# Altium schematic sheet 025_RTL8305&LANPORT.SchDoc(025_RTL8305&LANPORT)
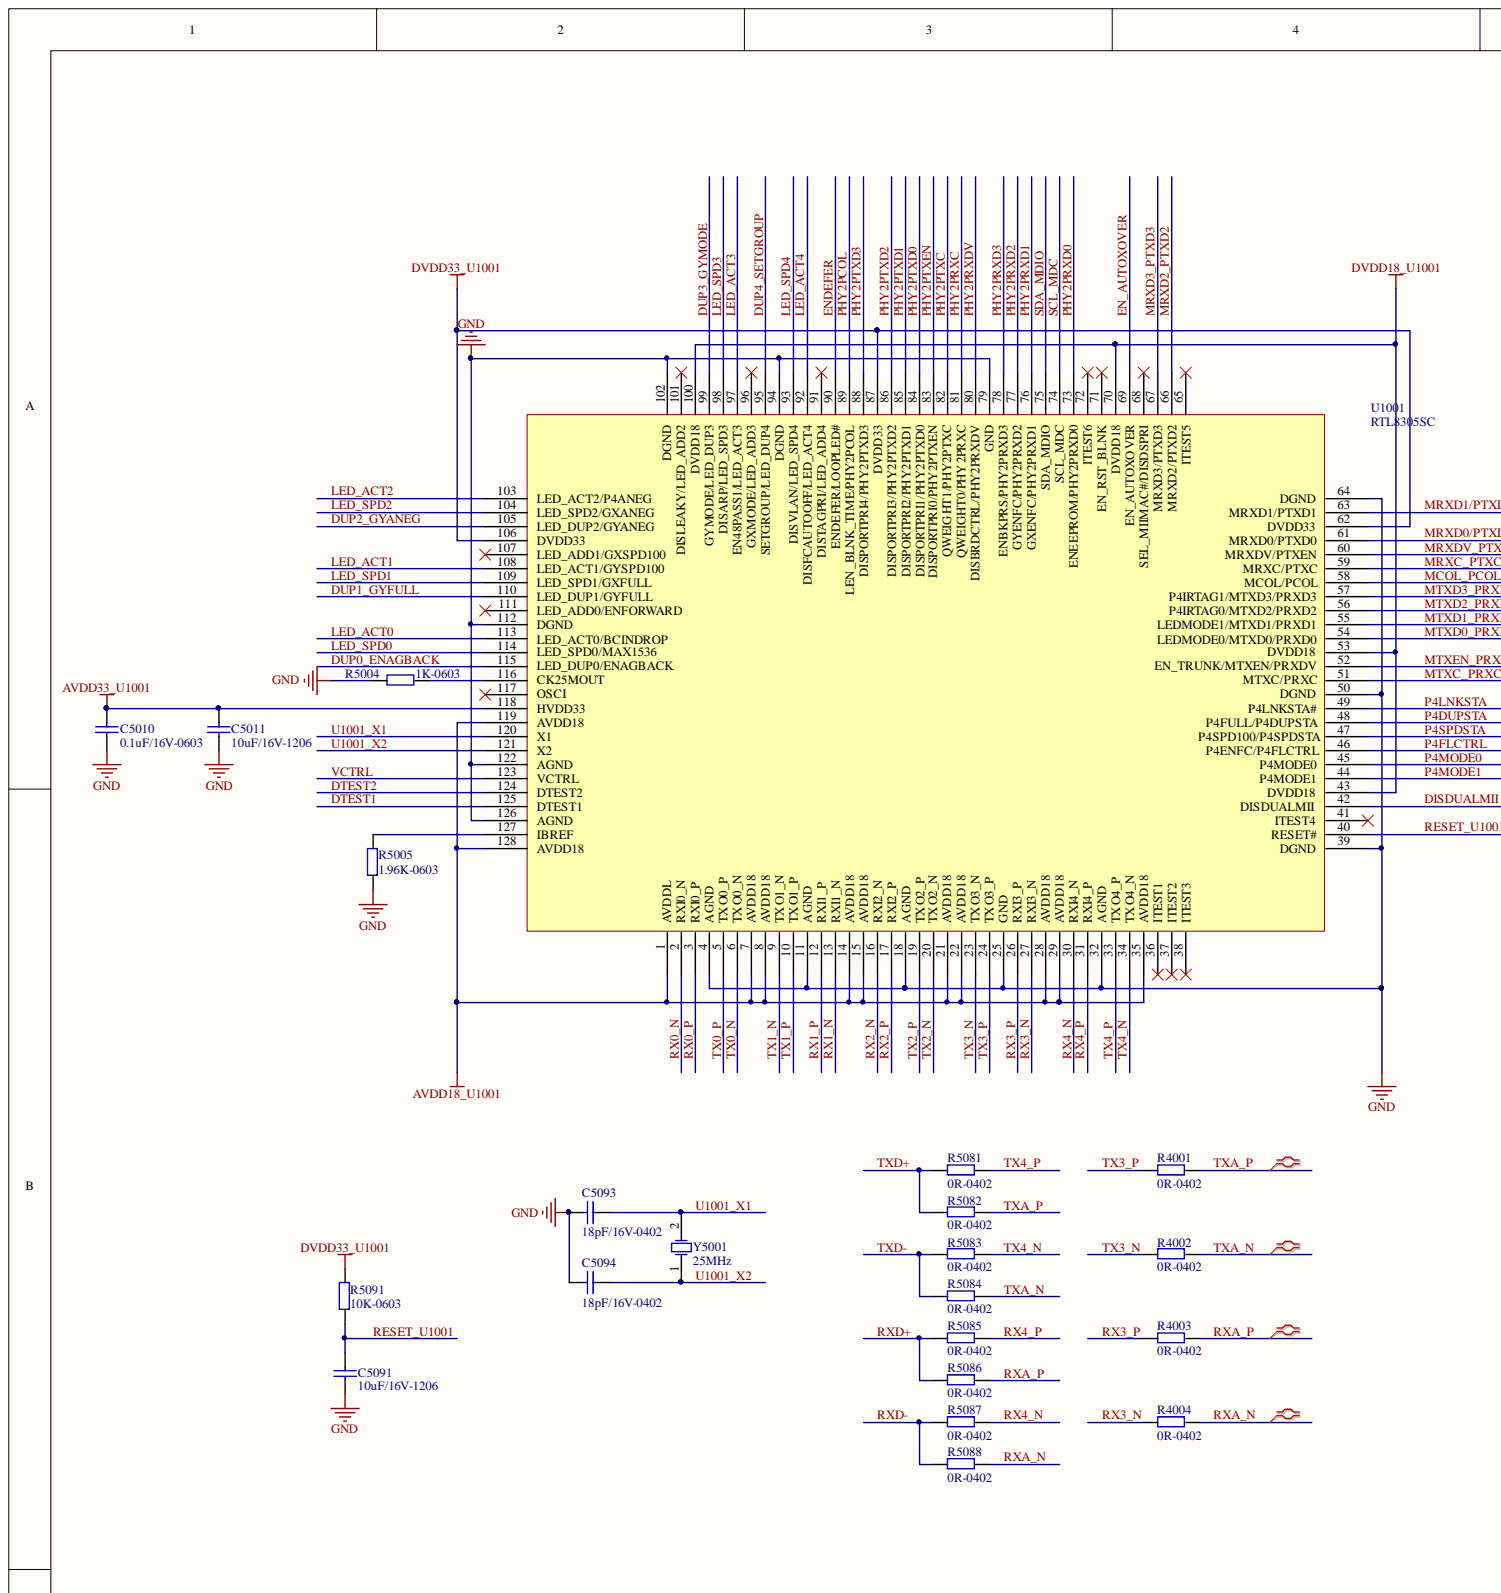
[diagram p0: 025_RTL8305&LANPORT.SchDoc, top left]
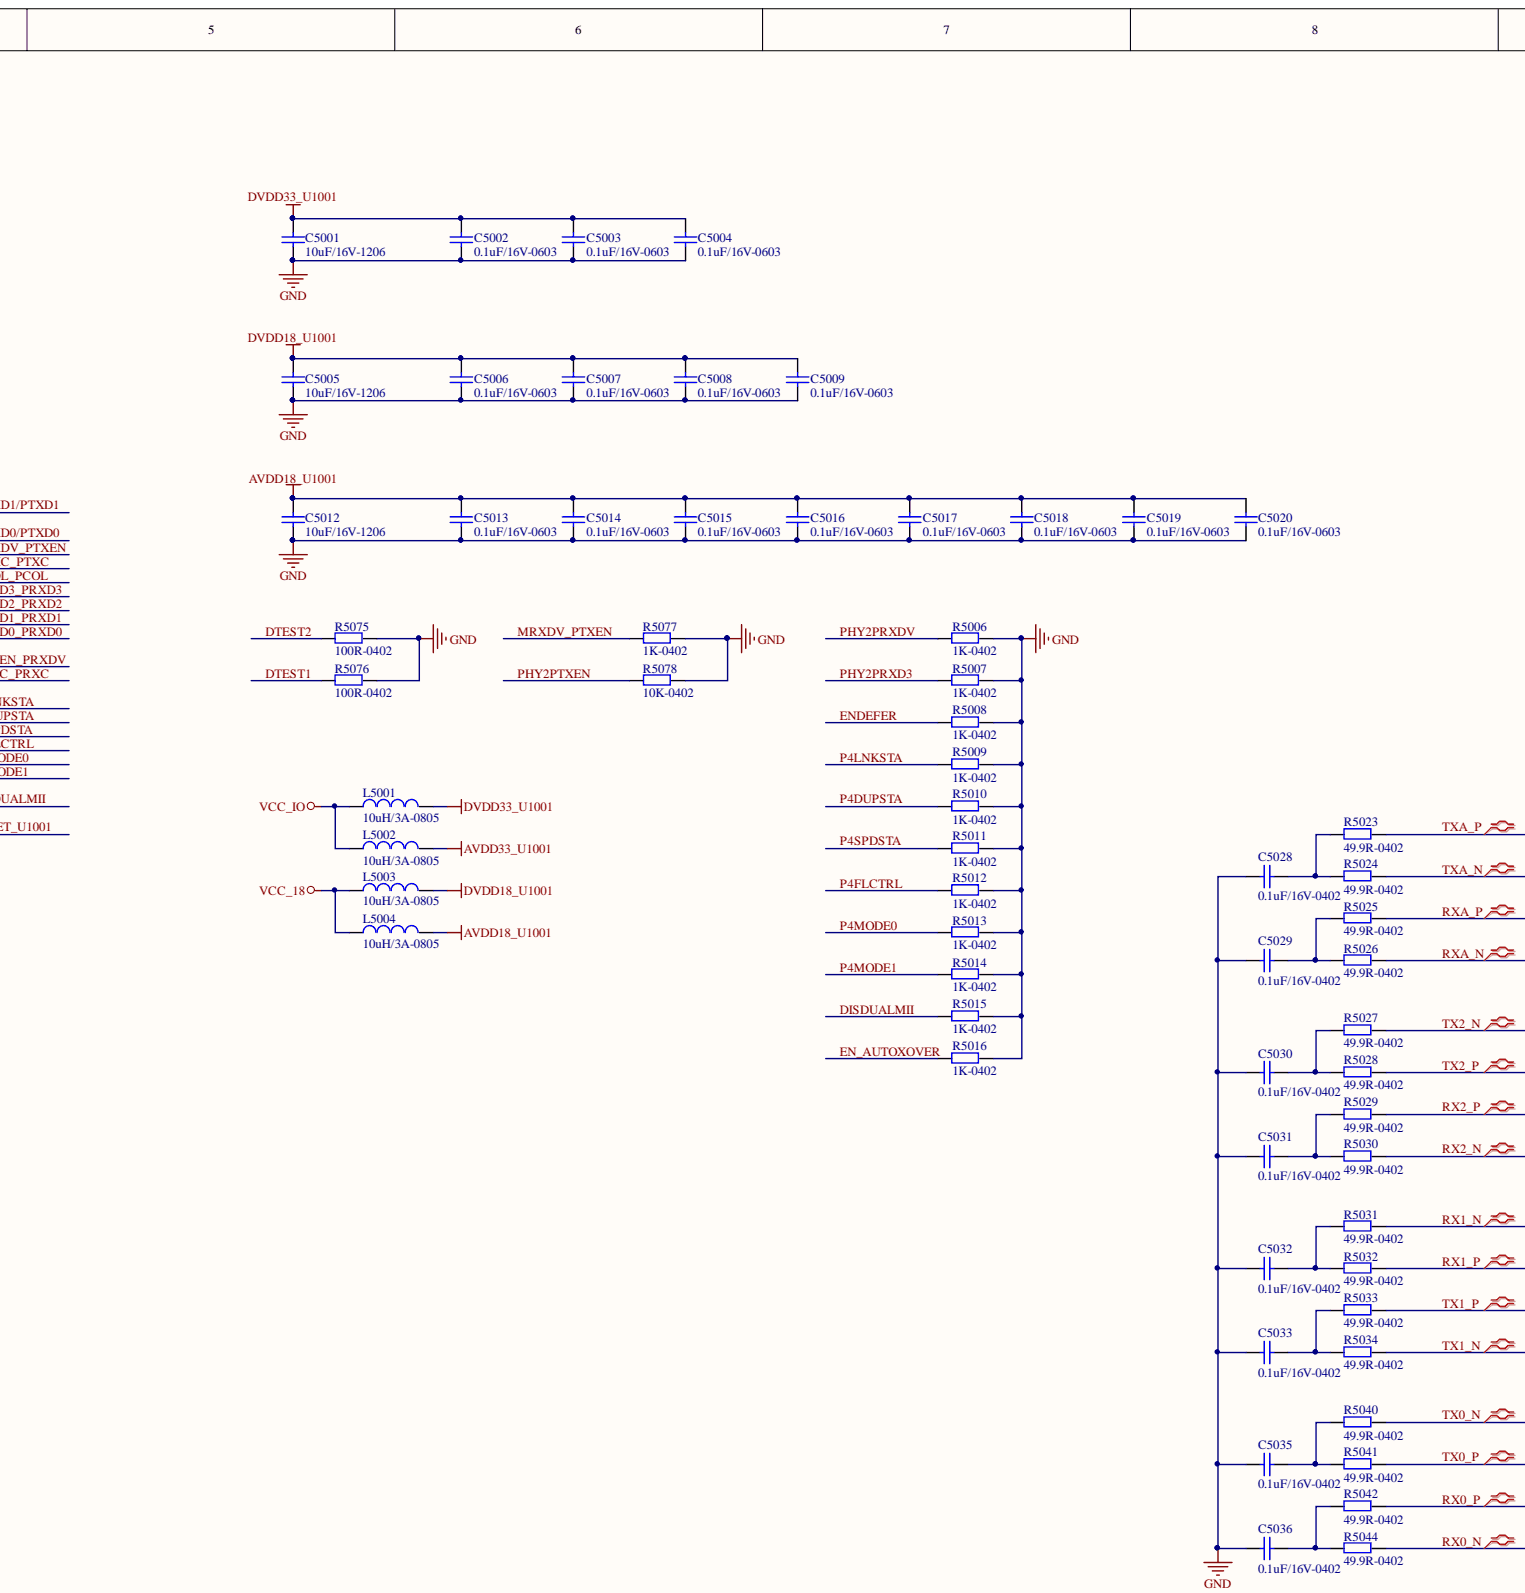
[diagram p1: 025_RTL8305&LANPORT.SchDoc, top centre]
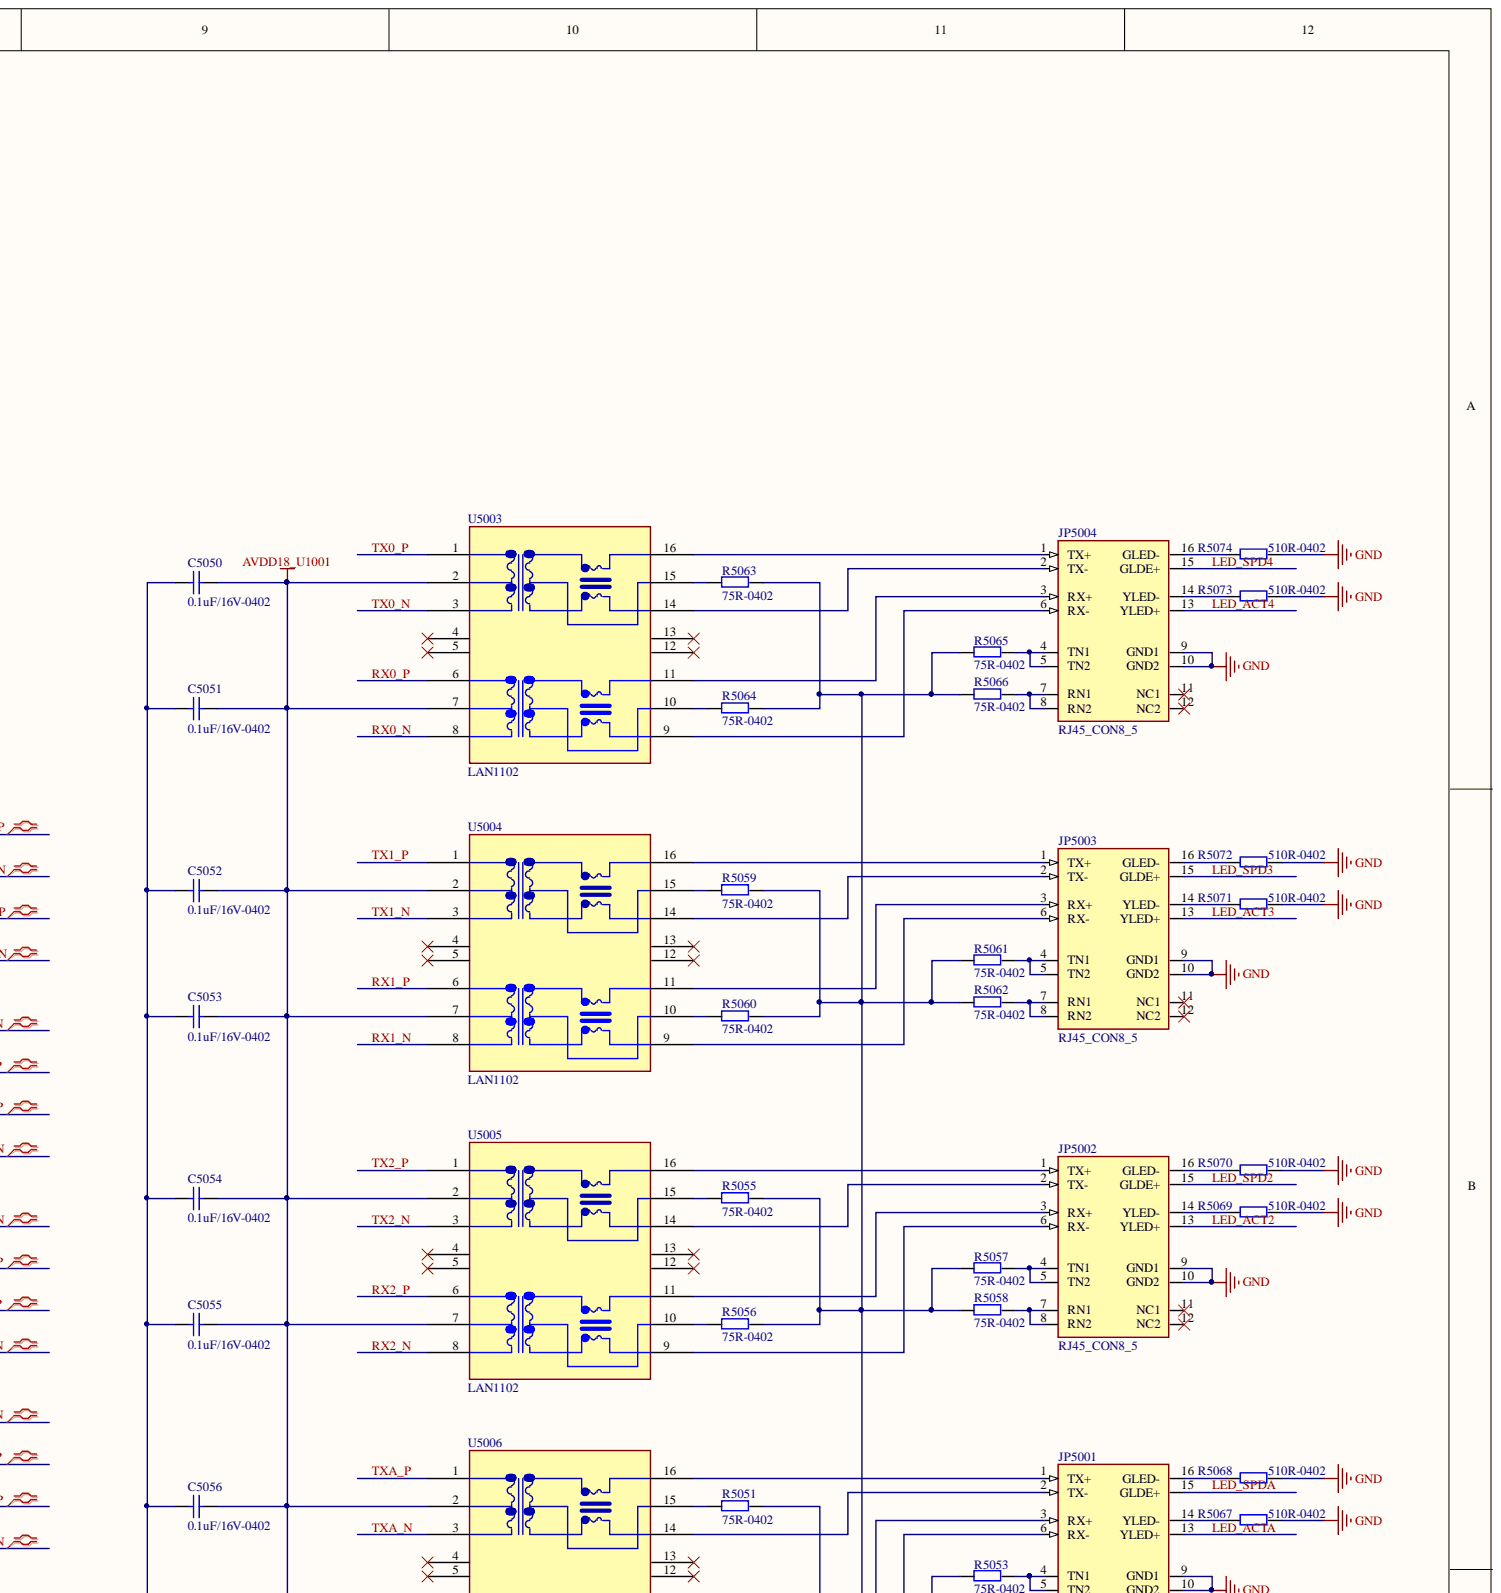
[diagram p2: 025_RTL8305&LANPORT.SchDoc, top right]
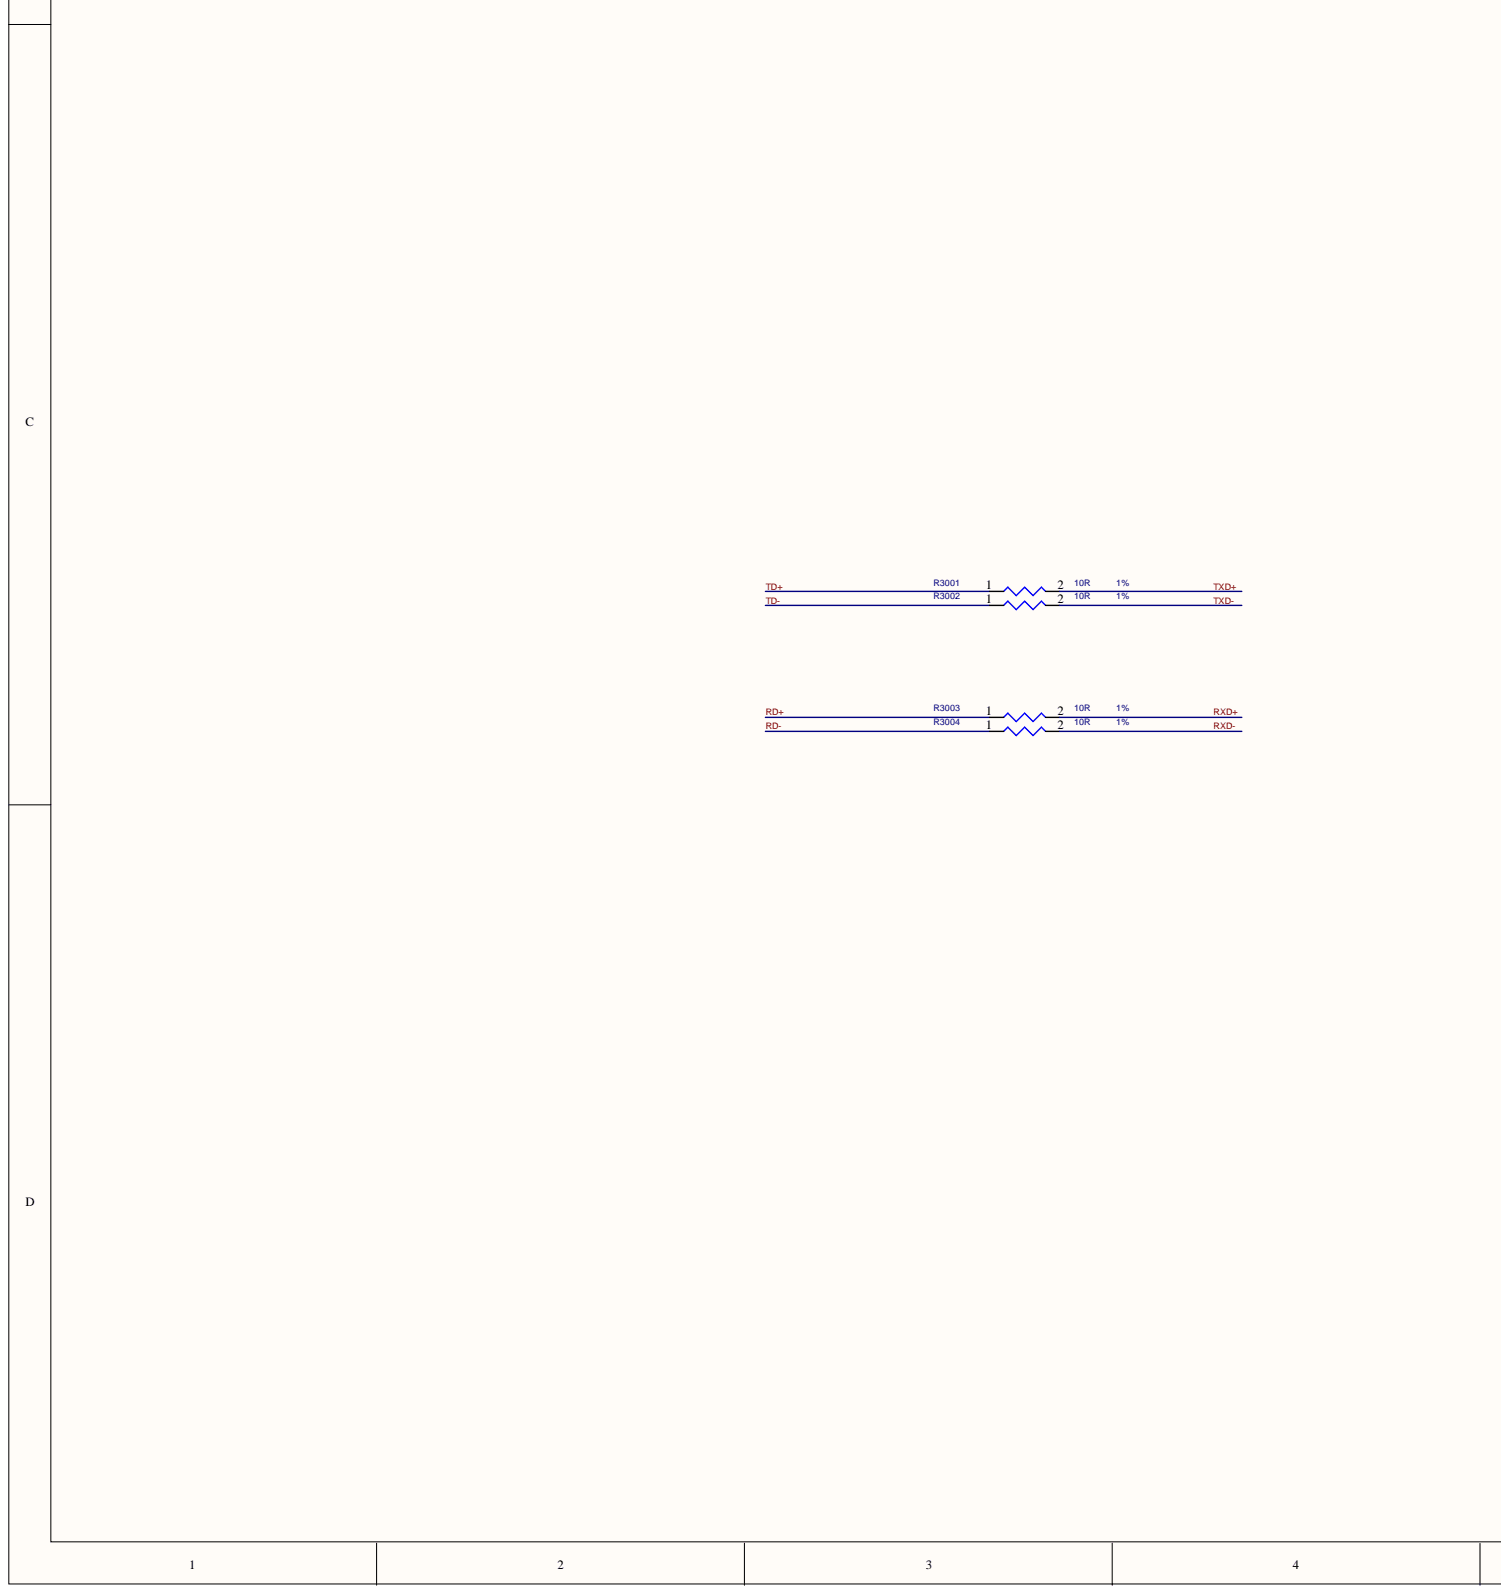
[diagram p3: 025_RTL8305&LANPORT.SchDoc, bottom left]
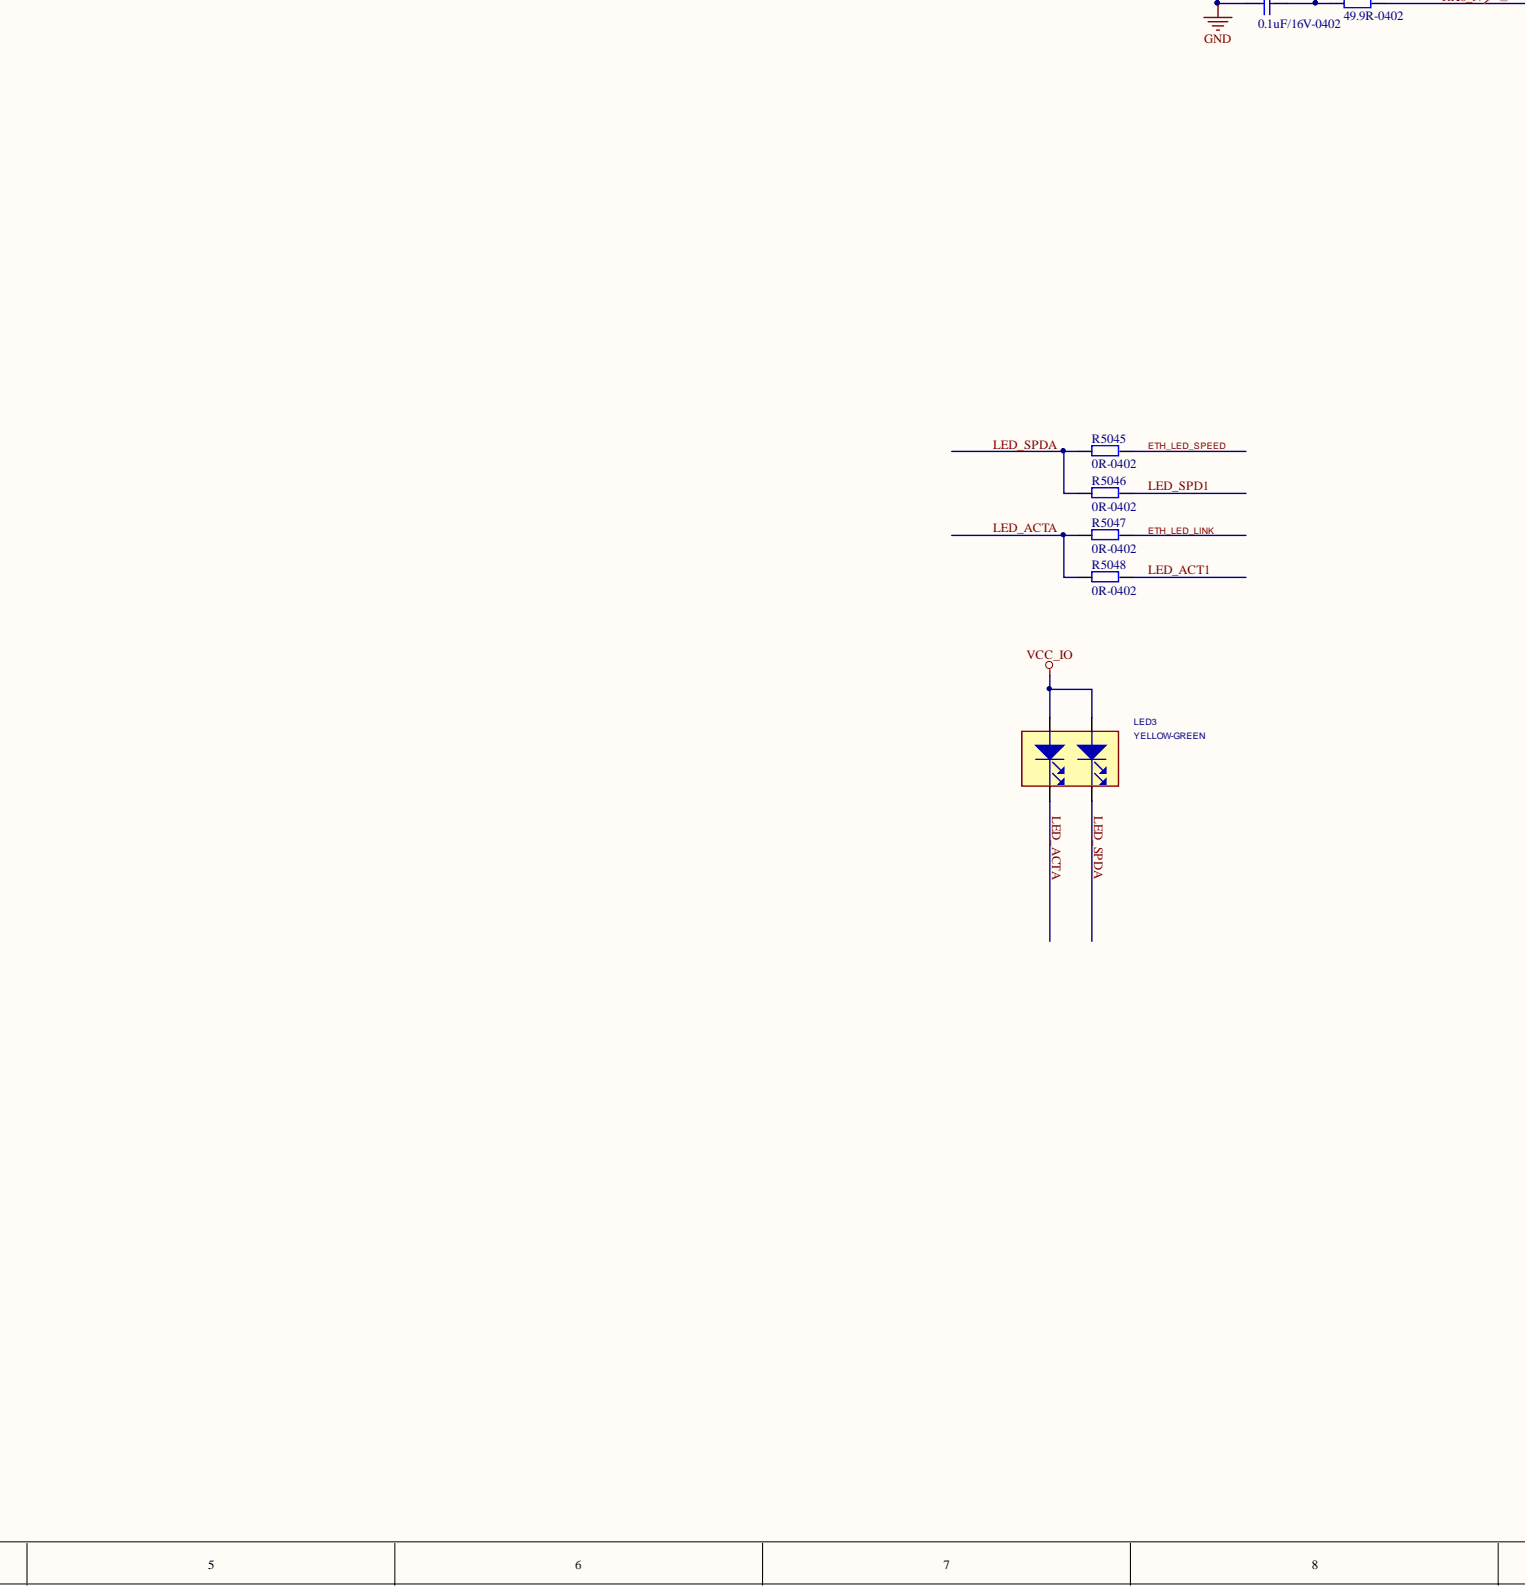
[diagram p4: 025_RTL8305&LANPORT.SchDoc, bottom centre]
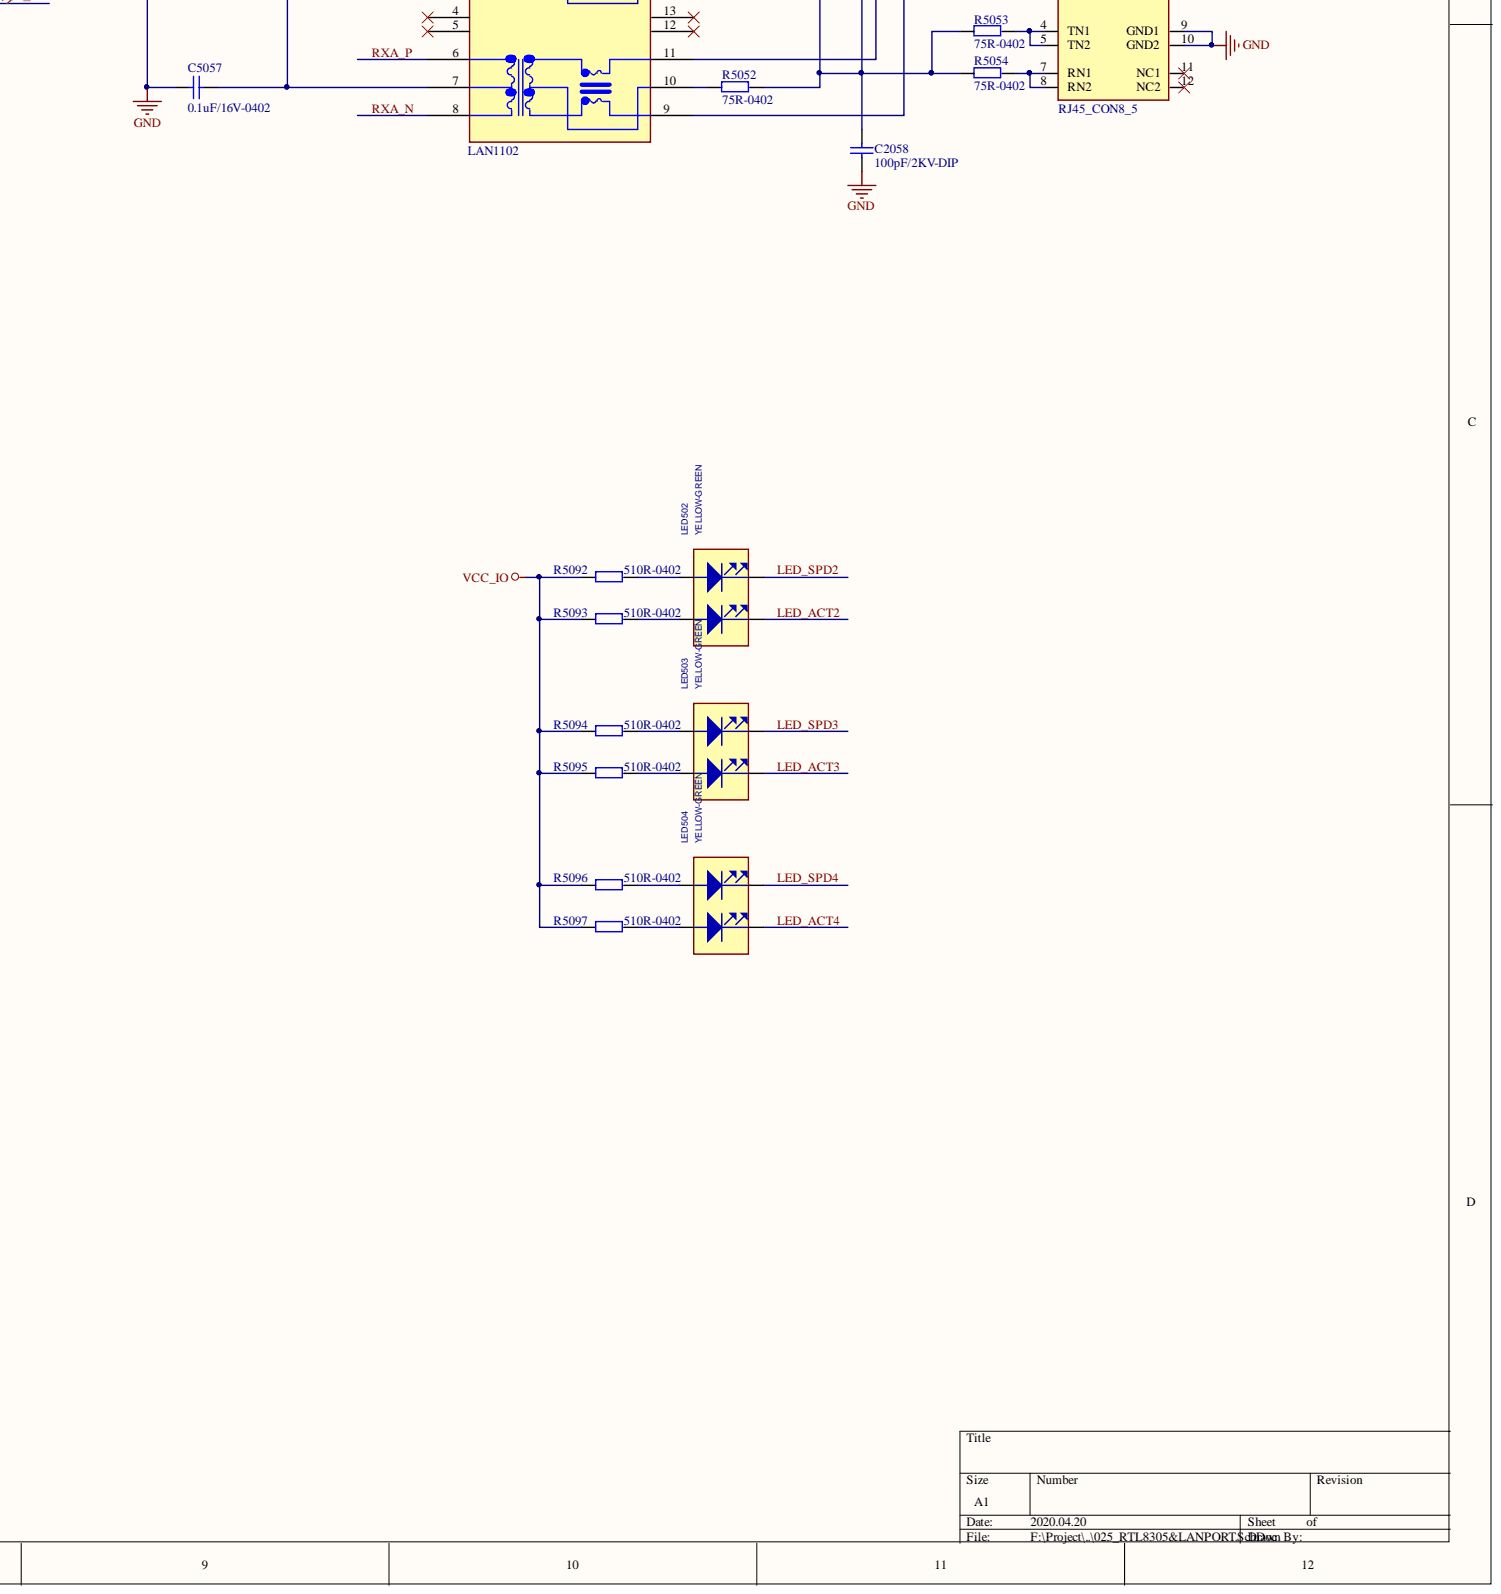
[diagram p5: 025_RTL8305&LANPORT.SchDoc, bottom right]

## Parts
C2058  100pF/2KV-DIP  [RAD-0.1]
C5001  10uF/16V-1206  [C1206]
C5002  0.1uF/16V-0603  [C0603]
C5003  0.1uF/16V-0603  [C0603]
C5004  0.1uF/16V-0603  [C0603]
C5005  10uF/16V-1206  [C1206]
C5006  0.1uF/16V-0603  [C0603]
C5007  0.1uF/16V-0603  [C0603]
C5008  0.1uF/16V-0603  [C0603]
C5009  0.1uF/16V-0603  [C0603]
C5010  0.1uF/16V-0603  [C0603]
C5011  10uF/16V-1206  [C1206]
C5012  10uF/16V-1206  [C1206]
C5013  0.1uF/16V-0603  [C0603]
C5014  0.1uF/16V-0603  [C0603]
C5015  0.1uF/16V-0603  [C0603]
C5016  0.1uF/16V-0603  [C0603]
C5017  0.1uF/16V-0603  [C0603]
C5018  0.1uF/16V-0603  [C0603]
C5019  0.1uF/16V-0603  [C0603]
C5020  0.1uF/16V-0603  [C0603]
C5028  0.1uF/16V-0402  [C0402]
C5029  0.1uF/16V-0402  [C0402]
C5030  0.1uF/16V-0402  [C0402]
C5031  0.1uF/16V-0402  [C0402]
C5032  0.1uF/16V-0402  [C0402]
C5033  0.1uF/16V-0402  [C0402]
C5035  0.1uF/16V-0402  [C0402]
C5036  0.1uF/16V-0402  [C0402]
C5050  0.1uF/16V-0402  [C0402]
C5051  0.1uF/16V-0402  [C0402]
C5052  0.1uF/16V-0402  [C0402]
C5053  0.1uF/16V-0402  [C0402]
C5054  0.1uF/16V-0402  [C0402]
C5055  0.1uF/16V-0402  [C0402]
C5056  0.1uF/16V-0402  [C0402]
C5057  0.1uF/16V-0402  [C0402]
C5091  10uF/16V-1206  [C1206]
C5093  18pF/16V-0402  [C0402]
C5094  18pF/16V-0402  [C0402]
JP5001  RJ45_CON8_5  [RJ-45B]
JP5002  RJ45_CON8_5  [RJ-45B]
JP5003  RJ45_CON8_5  [RJ-45B]
JP5004  RJ45_CON8_5  [RJ-45B]
L5001  10uH/3A-0805  [I0805]
L5002  10uH/3A-0805  [I0805]
L5003  10uH/3A-0805  [I0805]
L5004  10uH/3A-0805  [I0805]
LED3  2ND: YELLOW-GREEN  [LED254_2P]  (hidden comment: LED_R)
LED502  2ND: YELLOW-GREEN  [LED254_2P]  (hidden comment: LED_R)
LED503  2ND: YELLOW-GREEN  [LED254_2P]  (hidden comment: LED_R)
LED504  2ND: YELLOW-GREEN  [LED254_2P]  (hidden comment: LED_R)
R3001  10R  Tolerance: 1%  [R0402]
R3002  10R  Tolerance: 1%  [R0402]
R3003  10R  Tolerance: 1%  [R0402]
R3004  10R  Tolerance: 1%  [R0402]
R4001  0R-0402  [R0402]
R4002  0R-0402  [R0402]
R4003  0R-0402  [R0402]
R4004  0R-0402  [R0402]
R5004  1K-0603  [R0603]
R5005  1.96K-0603  [R0603]
R5006  1K-0402  [R0402]
R5007  1K-0402  [R0402]
R5008  1K-0402  [R0402]
R5009  1K-0402  [R0402]
R5010  1K-0402  [R0402]
R5011  1K-0402  [R0402]
R5012  1K-0402  [R0402]
R5013  1K-0402  [R0402]
R5014  1K-0402  [R0402]
R5015  1K-0402  [R0402]
R5016  1K-0402  [R0402]
R5023  49.9R-0402  [R0402]
R5024  49.9R-0402  [R0402]
R5025  49.9R-0402  [R0402]
R5026  49.9R-0402  [R0402]
R5027  49.9R-0402  [R0402]
R5028  49.9R-0402  [R0402]
R5029  49.9R-0402  [R0402]
R5030  49.9R-0402  [R0402]
R5031  49.9R-0402  [R0402]
R5032  49.9R-0402  [R0402]
R5033  49.9R-0402  [R0402]
R5034  49.9R-0402  [R0402]
R5040  49.9R-0402  [R0402]
R5041  49.9R-0402  [R0402]
R5042  49.9R-0402  [R0402]
R5044  49.9R-0402  [R0402]
R5045  0R-0402  [R0402]
R5046  0R-0402  [R0402]
R5047  0R-0402  [R0402]
R5048  0R-0402  [R0402]
R5051  75R-0402  [R0402]
R5052  75R-0402  [R0402]
R5053  75R-0402  [R0402]
R5054  75R-0402  [R0402]
R5055  75R-0402  [R0402]
R5056  75R-0402  [R0402]
R5057  75R-0402  [R0402]
R5058  75R-0402  [R0402]
R5059  75R-0402  [R0402]
R5060  75R-0402  [R0402]
R5061  75R-0402  [R0402]
R5062  75R-0402  [R0402]
R5063  75R-0402  [R0402]
R5064  75R-0402  [R0402]
R5065  75R-0402  [R0402]
R5066  75R-0402  [R0402]
R5067  510R-0402  [R0402]
R5068  510R-0402  [R0402]
R5069  510R-0402  [R0402]
R5070  510R-0402  [R0402]
R5071  510R-0402  [R0402]
R5072  510R-0402  [R0402]
R5073  510R-0402  [R0402]
R5074  510R-0402  [R0402]
R5075  100R-0402  [R0402]
R5076  100R-0402  [R0402]
R5077  1K-0402  [R0402]
R5078  10K-0402  [R0402]
R5081  0R-0402  [R0402]
R5082  0R-0402  [R0402]
R5083  0R-0402  [R0402]
R5084  0R-0402  [R0402]
R5085  0R-0402  [R0402]
R5086  0R-0402  [R0402]
R5087  0R-0402  [R0402]
R5088  0R-0402  [R0402]
R5091  10K-0603  [R0603]
R5092  510R-0402  [R0402]
R5093  510R-0402  [R0402]
R5094  510R-0402  [R0402]
R5095  510R-0402  [R0402]
R5096  510R-0402  [R0402]
R5097  510R-0402  [R0402]
U1001  RTL8305SC  [PQFP-128N]
U5003  LAN1102  [SOIC16]
U5004  LAN1102  [SOIC16]
U5005  LAN1102  [SOIC16]
U5006  LAN1102  [SOIC16]
Y5001  25MHz  [XTAL-RU49/4]

## Nets
AVDD18_U1001: C5012.2 C5013.2 C5014.2 C5015.2 C5016.2 C5017.2 C5018.2 C5019.2 C5020.2 C5050.2 C5051.2 C5052.2 C5053.2 C5054.2 C5055.2 C5056.2 C5057.2 L5004.2 U1001.1(AVDDL) U1001.7(AVDD18) U1001.8(AVDD18) U1001.14(AVDD18) U1001.15(AVDD18) U1001.21(AVDD18) U1001.22(AVDD18) U1001.28(AVDD18) U1001.29 U1001.35(AVDD18) U1001.119(AVDD18) U1001.128(AVDD18) U5003.2 U5003.7 U5004.2 U5004.7 U5005.2 U5005.7 U5006.2 U5006.7
AVDD33_U1001: C5010.2 C5011.2 L5002.2 U1001.118(HVDD33)
DVDD18_U1001: C5005.2 C5006.2 C5007.2 C5008.2 C5009.2 L5003.2 U1001.43(DVDD18) U1001.53(DVDD18) U1001.70 U1001.100(DVDD18)
DVDD33_U1001: C5001.2 C5002.2 C5003.2 C5004.2 L5001.2 R5091.2 U1001.62(DVDD33) U1001.87(DVDD33) U1001.106(DVDD33)
GND: C2058.1 C5001.1 C5002.1 C5003.1 C5004.1 C5005.1 C5006.1 C5007.1 C5008.1 C5009.1 C5010.1 C5011.1 C5012.1 C5013.1 C5014.1 C5015.1 C5016.1 C5017.1 C5018.1 C5019.1 C5020.1 C5028.1 C5029.1 C5030.1 C5031.1 C5032.1 C5033.1 C5035.1 C5036.1 C5050.1 C5051.1 C5052.1 C5053.1 C5054.1 C5055.1 C5056.1 C5057.1 C5091.1 C5093.2 C5094.2 JP5001.9(GND1) JP5001.10(GND2) JP5002.9(GND1) JP5002.10(GND2) JP5003.9(GND1) JP5003.10(GND2) JP5004.9(GND1) JP5004.10(GND2) R5004.1 R5005.1 R5006.2 R5007.2 R5008.2 R5009.2 R5010.2 R5011.2 R5012.2 R5013.2 R5014.2 R5015.2 R5016.2 R5067.2 R5068.2 R5069.2 R5070.2 R5071.2 R5072.2 R5073.2 R5074.2 R5075.1 R5076.1 R5077.1 R5078.1 U1001.4(AGND) U1001.11(AGND) U1001.18 U1001.25(GND) U1001.32(AGND) U1001.39(DGND) U1001.50(DGND) U1001.64(DGND) U1001.79(GND) U1001.94 U1001.102(DGND) U1001.112(DGND) U1001.122(AGND) U1001.126(AGND)
VCC_18: L5003.1 L5004.1
VCC_IO: L5001.1 L5002.1 LED3.1 LED3.3 R5092.1 R5093.1 R5094.1 R5095.1 R5096.1 R5097.1
DISDUALMII: R5015.1 U1001.42(DISDUALMII)
DTEST1: R5076.2 U1001.125(DTEST1)
DTEST2: R5075.2 U1001.124(DTEST2)
DUP0_ENAGBACK: U1001.115(LED_DUP0/ENAGBACK)
DUP1_GYFULL: U1001.110(LED_DUP1/GYFULL)
DUP2_GYANEG: U1001.105(LED_DUP2/GYANEG)
DUP3_GYMODE: U1001.99(GYMODE/LED_DUP3)
DUP4_SETGROUP: U1001.95(SETGROUP/LED_DUP4)
EN_AUTOXOVER: R5016.1 U1001.69(EN_AUTOXOVER)
ENDEFER: R5008.1 U1001.90
ETH_LED_LINK: R5047.2
ETH_LED_SPEED: R5045.2
LED_ACT0: U1001.113(LED_ACT0/BCINDROP)
LED_ACT1: R5048.2 U1001.108(LED_ACT1/GYSPD100)
LED_ACT2: JP5002.13(YLED+) LED502.2 U1001.103(LED_ACT2/P4ANEG)
LED_ACT3: JP5003.13(YLED+) LED503.2 U1001.97(EN48PASS1/LED_ACT3)
LED_ACT4: JP5004.13(YLED+) LED504.2 U1001.92(DISFCAUTOOFF/LED_ACT4)
LED_ACTA: JP5001.13(YLED+) LED3.2 R5047.1 R5048.1
LED_SPD0: U1001.114(LED_SPD0/MAX1536)
LED_SPD1: R5046.2 U1001.109(LED_SPD1/GXFULL)
LED_SPD2: JP5002.15(GLDE+) LED502.4 U1001.104(LED_SPD2/GXANEG)
LED_SPD3: JP5003.15(GLDE+) LED503.4 U1001.98(DISARP/LED_SPD3)
LED_SPD4: JP5004.15(GLDE+) LED504.4 U1001.93(DISVLAN/LED_SPD4)
LED_SPDA: JP5001.15(GLDE+) LED3.4 R5045.1 R5046.1
MCOL_PCOL: U1001.58(MCOL/PCOL)
MRXC_PTXC: U1001.59(MRXC/PTXC)
MRXD0/PTXD0: U1001.61(MRXD0/PTXD0)
MRXD1/PTXD1: U1001.63(MRXD1/PTXD1)
MRXD2_PTXD2: U1001.66(MRXD2/PTXD2)
MRXD3_PTXD3: U1001.67
MRXDV_PTXEN: R5077.2 U1001.60(MRXDV/PTXEN)
MTXC_PRXC: U1001.51(MTXC/PRXC)
MTXD0_PRXD0: U1001.54(LEDMODE0/MTXD0/PRXD0)
MTXD1_PRXD1: U1001.55(LEDMODE1/MTXD1/PRXD1)
MTXD2_PRXD2: U1001.56(P4IRTAG0/MTXD2/PRXD2)
MTXD3_PRXD3: U1001.57(P4IRTAG1/MTXD3/PRXD3)
MTXEN_PRXDV: U1001.52(EN_TRUNK/MTXEN/PRXDV)
P4DUPSTA: R5010.1 U1001.48(P4FULL/P4DUPSTA)
P4FLCTRL: R5012.1 U1001.46(P4ENFC/P4FLCTRL)
P4LNKSTA: R5009.1 U1001.49(P4LNKSTA#)
P4MODE0: R5013.1 U1001.45(P4MODE0)
P4MODE1: R5014.1 U1001.44(P4MODE1)
P4SPDSTA: R5011.1 U1001.47(P4SPD100/P4SPDSTA)
PHY2PCOL: U1001.89(LEN_BLNK_TIME/PHY2PCOL)
PHY2PRXC: U1001.81(QWEIGHT0/PHY2PRXC)
PHY2PRXD0: U1001.73(ENEEPROM/PHY2PRXD0)
PHY2PRXD1: U1001.76(GXENFC/PHY2PRXD1)
PHY2PRXD2: U1001.77(GYENFC/PHY2PRXD2)
PHY2PRXD3: R5007.1 U1001.78(ENBKPRS/PHY2PRXD3)
PHY2PRXDV: R5006.1 U1001.80(DISBRDCTRL/PHY2PRXDV)
PHY2PTXC: U1001.82(QWEIGHT1/PHY2PTXC)
PHY2PTXD0: U1001.84(DISPORTPRI1/PHY2PTXD0)
PHY2PTXD1: U1001.85
PHY2PTXD2: U1001.86
PHY2PTXD3: U1001.88(DISPORTPRI4/PHY2PTXD3)
PHY2PTXEN: R5078.2 U1001.83(DISPORTPRI0/PHY2PTXEN)
RD+: R3003.1
RD-: R3004.1
RESET_U1001: C5091.2 R5091.1 U1001.40(RESET#)
RX0_N: R5044.2 U1001.2 U5003.8
RX0_P: R5042.2 U1001.3(RXI0_P) U5003.6
RX1_N: R5031.2 U1001.13 U5004.8
RX1_P: R5032.2 U1001.12(RXI1_P) U5004.6
RX2_N: R5030.2 U1001.16(RXI2_N) U5005.8
RX2_P: R5029.2 U1001.17 U5005.6
RX3_N: R4004.1 U1001.27(RXI3_N)
RX3_P: R4003.1 U1001.26(RXI3_P)
RX4_N: R5087.2 U1001.30(RXI4_N)
RX4_P: R5085.2 U1001.31(RXI4_P)
RXA_N: R4004.2 R5026.2 R5088.2 U5006.8
RXA_P: R4003.2 R5025.2 R5086.2 U5006.6
RXD+: R3003.2 R5085.1 R5086.1
RXD-: R3004.2 R5087.1 R5088.1
SCL_MDC: U1001.74
SDA_MDIO: U1001.75(SDA_MDIO)
TD+: R3001.1
TD-: R3002.1
TX0_N: R5040.2 U1001.6(TXO0_N) U5003.3
TX0_P: R5041.2 U1001.5(TXO0_P) U5003.1
TX1_N: R5034.2 U1001.9 U5004.3
TX1_P: R5033.2 U1001.10(TXO1_P) U5004.1
TX2_N: R5027.2 U1001.20(TXO2_N) U5005.3
TX2_P: R5028.2 U1001.19(TXO2_P) U5005.1
TX3_N: R4002.1 U1001.23(TXO3_N)
TX3_P: R4001.1 U1001.24(TXO3_P)
TX4_N: R5083.2 U1001.34(TXO4_N)
TX4_P: R5081.2 U1001.33
TXA_N: R4002.2 R5024.2 R5084.2 U5006.3
TXA_P: R4001.2 R5023.2 R5082.2 U5006.1
TXD+: R3001.2 R5081.1 R5082.1
TXD-: R3002.2 R5083.1 R5084.1
U1001_X1: C5093.1 U1001.120(X1) Y5001.2
U1001_X2: C5094.1 U1001.121(X2) Y5001.1
VCTRL: U1001.123(VCTRL)
NetC2058_2: C2058.2 R5051.1 R5052.1 R5053.2 R5054.2 R5055.1 R5056.1 R5057.2 R5058.2 R5059.1 R5060.1 R5061.2 R5062.2 R5063.1 R5064.1 R5065.2 R5066.2
NetC5028_2: C5028.2 R5023.1 R5024.1
NetC5029_2: C5029.2 R5025.1 R5026.1
NetC5030_2: C5030.2 R5027.1 R5028.1
NetC5031_2: C5031.2 R5029.1 R5030.1
NetC5032_2: C5032.2 R5031.1 R5032.1
NetC5033_2: C5033.2 R5033.1 R5034.1
NetC5035_2: C5035.2 R5040.1 R5041.1
NetC5036_2: C5036.2 R5042.1 R5044.1
NetJP5001_1: JP5001.1(TX+) U5006.16
NetJP5001_2: JP5001.2(TX-) U5006.14
NetJP5001_3: JP5001.3(RX+) U5006.11
NetJP5001_4: JP5001.4(TN1) JP5001.5(TN2) R5053.1
NetJP5001_6: JP5001.6(RX-) U5006.9
NetJP5001_7: JP5001.7(RN1) JP5001.8(RN2) R5054.1
NetJP5001_14: JP5001.14(YLED-) R5067.1
NetJP5001_16: JP5001.16(GLED-) R5068.1
NetJP5002_1: JP5002.1(TX+) U5005.16
NetJP5002_2: JP5002.2(TX-) U5005.14
NetJP5002_3: JP5002.3(RX+) U5005.11
NetJP5002_4: JP5002.4(TN1) JP5002.5(TN2) R5057.1
NetJP5002_6: JP5002.6(RX-) U5005.9
NetJP5002_7: JP5002.7(RN1) JP5002.8(RN2) R5058.1
NetJP5002_14: JP5002.14(YLED-) R5069.1
NetJP5002_16: JP5002.16(GLED-) R5070.1
NetJP5003_1: JP5003.1(TX+) U5004.16
NetJP5003_2: JP5003.2(TX-) U5004.14
NetJP5003_3: JP5003.3(RX+) U5004.11
NetJP5003_4: JP5003.4(TN1) JP5003.5(TN2) R5061.1
NetJP5003_6: JP5003.6(RX-) U5004.9
NetJP5003_7: JP5003.7(RN1) JP5003.8(RN2) R5062.1
NetJP5003_14: JP5003.14(YLED-) R5071.1
NetJP5003_16: JP5003.16(GLED-) R5072.1
NetJP5004_1: JP5004.1(TX+) U5003.16
NetJP5004_2: JP5004.2(TX-) U5003.14
NetJP5004_3: JP5004.3(RX+) U5003.11
NetJP5004_4: JP5004.4(TN1) JP5004.5(TN2) R5065.1
NetJP5004_6: JP5004.6(RX-) U5003.9
NetJP5004_7: JP5004.7(RN1) JP5004.8(RN2) R5066.1
NetJP5004_14: JP5004.14(YLED-) R5073.1
NetJP5004_16: JP5004.16(GLED-) R5074.1
NetLED502_1: LED502.1 R5093.2
NetLED502_3: LED502.3 R5092.2
NetLED503_1: LED503.1 R5095.2
NetLED503_3: LED503.3 R5094.2
NetLED504_1: LED504.1 R5097.2
NetLED504_3: LED504.3 R5096.2
NetR5004_2: R5004.2 U1001.116(CK25MOUT)
NetR5005_2: R5005.2 U1001.127(IBREF)
NetR5051_2: R5051.2 U5006.15
NetR5052_2: R5052.2 U5006.10
NetR5055_2: R5055.2 U5005.15
NetR5056_2: R5056.2 U5005.10
NetR5059_2: R5059.2 U5004.15
NetR5060_2: R5060.2 U5004.10
NetR5063_2: R5063.2 U5003.15
NetR5064_2: R5064.2 U5003.10

Not connected: JP5001 11(NC1) 12(NC2); JP5002 11(NC1) 12(NC2); JP5003 11(NC1) 12(NC2); JP5004 11(NC1) 12(NC2); U1001 36 37(ITEST2) 38(ITEST3) 41(ITEST4) 65(ITEST5) 68(SEL_MIIMAC#/DISDSPRI) 71(EN_RST_BLNK) 72(ITEST6) 91(DISTAGPRI/LED_ADD4) 96(GXMODE/LED_ADD3) 101 107(LED_ADD1/GXSPD100) 111(LED_ADD0/ENFORWARD) 117(OSCI); U5003 4 5 12 13; U5004 4 5 12 13; U5005 4 5 12 13; U5006 4 5 12 13

## Library data of the parts
C2058 C5001-C5020 C5028-C5033 C5035 C5036 C5050-C5057 C5091 C5093 C5094 = Cap: Capacitor; Simulation: CAP; Signal Integrity: Cap; Published: 8-Jun-2000; PackageReference: RAD-0.3; PackageDescription: Radial Cap, Thru-Hole; 2 Leads; 0.3 in Pin Spacing; Library Name: Miscellaneous Devices.IntLib; LatestRevisionNote: Re-released for DXP Platform.; LatestRevisionDate: 17-Jul-2002; Component Kind: Standard; Publisher: Altium Limited
  C2058: Value: 100pF/2KV
  C5001 C5005 C5011 C5012 C5091: Value: 10uF/16V
  C5002-C5004 C5006-C5010 C5013-C5020 C5028-C5033 C5035 C5036 C5050-C5057: Value: 0.1uF/16V
  C5093 C5094: Value: 18pF/16V
L5001-L5004 = Inductor: Code_IEC: 1005; Value: 10uH/3A; Simulation: INDUCTOR; Signal Integrity: Inductor; Published: 8-Jun-2000; PackageReference: 0402-A; PackageDescription: Chip Inductor; Library Name: Miscellaneous Devices.IntLib; LatestRevisionNote: IPC-7351 Footprint Added.; LatestRevisionDate: 29-May-2009; Description: Inductor; Component Kind: Standard; Code_JEITA: 0402; Publisher: Altium Limited
LED3 LED502-LED504 = LED_4: Entropic Part Number: 29-1120-0001; 1ST: <1ST>; Component Kind: Standard
R3001-R3004 = RESISTOR_Dup1: 哥棨슌훡롯量,0R,+/-5%,R0603,1/10W.; Manufacturer: YAGEO; Wattage: 1/10W; RK PN: RC0603JR-070RL; Priority: A; Part Type: 哥棨슌훡롯量; Manufacturer PN: RC0603JR-070RL; Component Kind: Standard; Created by: YTL
R4001-R4004 R5045-R5048 R5081-R5088 = Res2: Resistor; Value: 0R; Simulation: RESISTOR; Signal Integrity: Res; Published: 8-Jun-2000; PackageReference: AXIAL-0.4; PackageDescription: Axial Device, Thru-Hole; 2 Leads; 0.4 in Pin Spacing; Library Name: Miscellaneous Devices.IntLib; LatestRevisionNote: Re-released for DXP Platform.; LatestRevisionDate: 17-Jul-2002; Component Kind: Standard; Publisher: Altium Limited
R5004 R5006-R5016 R5077 = Res2: Resistor; Value: 1K; Simulation: RESISTOR; Signal Integrity: Res; Published: 8-Jun-2000; PackageReference: AXIAL-0.4; PackageDescription: Axial Device, Thru-Hole; 2 Leads; 0.4 in Pin Spacing; Library Name: Miscellaneous Devices.IntLib; LatestRevisionNote: Re-released for DXP Platform.; LatestRevisionDate: 17-Jul-2002; Component Kind: Standard; Publisher: Altium Limited
R5005 = Res2: Resistor; Value: 1.96K; Simulation: RESISTOR; Signal Integrity: Res; Published: 8-Jun-2000; PackageReference: AXIAL-0.4; PackageDescription: Axial Device, Thru-Hole; 2 Leads; 0.4 in Pin Spacing; Library Name: Miscellaneous Devices.IntLib; LatestRevisionNote: Re-released for DXP Platform.; LatestRevisionDate: 17-Jul-2002; Component Kind: Standard; Publisher: Altium Limited
R5023-R5034 R5040-R5042 R5044 = Res2: Resistor; Value: 49.9R; Simulation: RESISTOR; Signal Integrity: Res; Published: 8-Jun-2000; PackageReference: AXIAL-0.4; PackageDescription: Axial Device, Thru-Hole; 2 Leads; 0.4 in Pin Spacing; Library Name: Miscellaneous Devices.IntLib; LatestRevisionNote: Re-released for DXP Platform.; LatestRevisionDate: 17-Jul-2002; Component Kind: Standard; Publisher: Altium Limited
R5051-R5066 = Res2: Resistor; Value: 75R; Simulation: RESISTOR; Signal Integrity: Res; Published: 8-Jun-2000; PackageReference: AXIAL-0.4; PackageDescription: Axial Device, Thru-Hole; 2 Leads; 0.4 in Pin Spacing; Library Name: Miscellaneous Devices.IntLib; LatestRevisionNote: Re-released for DXP Platform.; LatestRevisionDate: 17-Jul-2002; Component Kind: Standard; Publisher: Altium Limited
R5067-R5074 R5092-R5097 = Res2: Resistor; Value: 510R; Simulation: RESISTOR; Signal Integrity: Res; Published: 8-Jun-2000; PackageReference: AXIAL-0.4; PackageDescription: Axial Device, Thru-Hole; 2 Leads; 0.4 in Pin Spacing; Library Name: Miscellaneous Devices.IntLib; LatestRevisionNote: Re-released for DXP Platform.; LatestRevisionDate: 17-Jul-2002; Component Kind: Standard; Publisher: Altium Limited
R5075 R5076 = Res2: Resistor; Value: 100R; Simulation: RESISTOR; Signal Integrity: Res; Published: 8-Jun-2000; PackageReference: AXIAL-0.4; PackageDescription: Axial Device, Thru-Hole; 2 Leads; 0.4 in Pin Spacing; Library Name: Miscellaneous Devices.IntLib; LatestRevisionNote: Re-released for DXP Platform.; LatestRevisionDate: 17-Jul-2002; Component Kind: Standard; Publisher: Altium Limited
R5078 R5091 = Res2: Resistor; Value: 10K; Simulation: RESISTOR; Signal Integrity: Res; Published: 8-Jun-2000; PackageReference: AXIAL-0.4; PackageDescription: Axial Device, Thru-Hole; 2 Leads; 0.4 in Pin Spacing; Library Name: Miscellaneous Devices.IntLib; LatestRevisionNote: Re-released for DXP Platform.; LatestRevisionDate: 17-Jul-2002; Component Kind: Standard; Publisher: Altium Limited
U1001 = RTL8305SC: SINGLE-CHIP 5-PORT 10/100MBPS SWITCH CONTROLLER WITH DUAL MII INTERFACES; PackageType: PQFP; PackageDrawing: PQFP-128N; OrderableDevice: RTL8305SC; Component Kind: Standard; Library Name: VTSG-203.SchLib
Y5001 = XTAL: Crystal Oscillator; Simulation: XTAL; Published: 8-Jun-2000; PackageReference: R38; PackageDescription: Crystal, Thru-Hole; 2 Leads; Body 3.1 x 8.2 mm (Dia.xH); Library Name: Miscellaneous Devices.IntLib; LatestRevisionNote: Re-released for DXP Platform.; LatestRevisionDate: 17-Jul-2002; Component Kind: Standard; Publisher: Altium Limited
JP5001-JP5004 = RJ45_CON8_5: Component Kind: Standard; Library Name: VTSG-104.SchLib
U5003-U5006 = LAN1102: Component Kind: Standard; Library Name: VTSG-104.SchLib
